# Altium schematic sheet EEPROM.SchDoc(EEPROM)
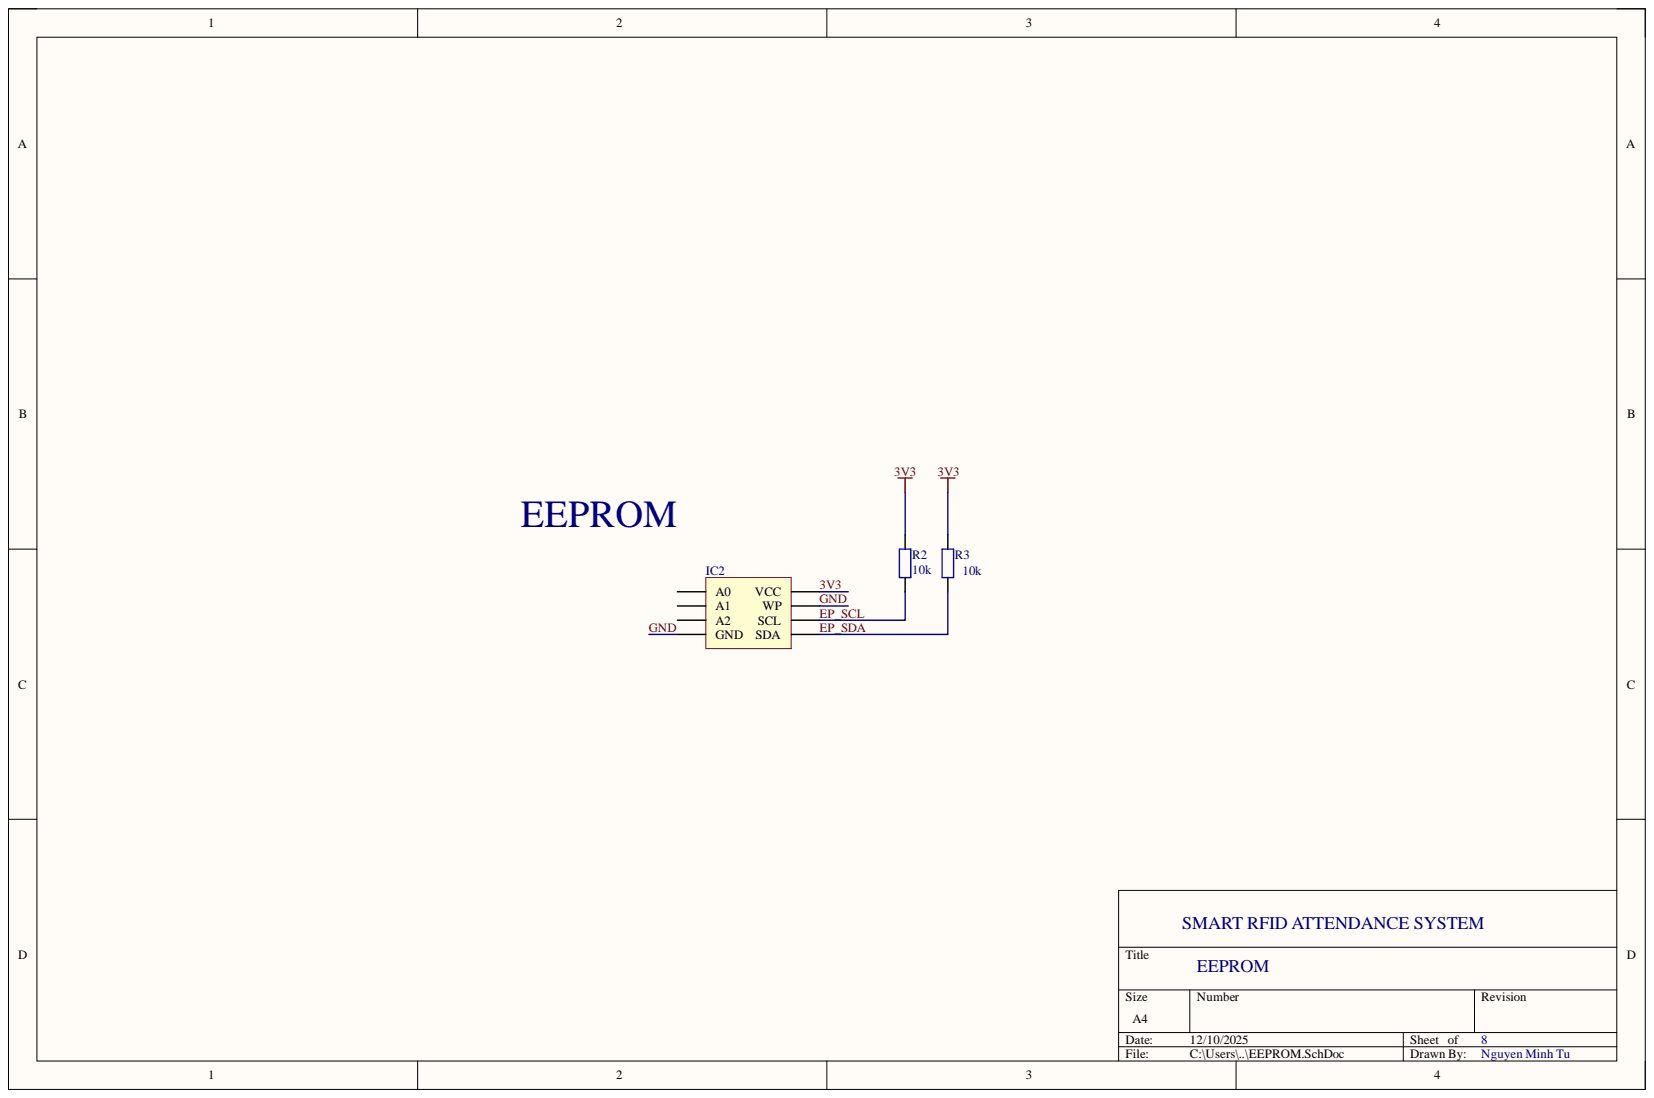
[diagram p0: EEPROM.SchDoc]

## Parts
IC2  MH002775  [DIP8]  (value not drawn)
R2  10k  [tro smd 0805]
R3  10k  [tro smd 0805]

## Nets
3V3: IC2.8(VCC) R2.2 R3.2
EP_SCL: IC2.6(SCL) R2.1
EP_SDA: IC2.5(SDA) R3.1
GND: IC2.4(GND) IC2.7(WP)

Not connected: IC2 1(A0) 2(A1) 3(A2)

## Library data of the parts
IC2 = AT24C01 DIP8 EEPROM: Cắm; Don_Vi: Con; Ten_Linh_Kien: AT24C01 DIP8 EEPROM 1KBIT; Chung_Loai: Cắm; Component Kind: Standard; Ma_Hang: MH002775; Library Name: Altium_Lib_by_KhueNguyenCreator.IntLib
R2 R3 = Tro 0805 1% 10k: Dán; Don_Vi: Con; Ma_Hang: MH005473; So_Chan: 2; Ten_Linh_Kien: Trở 0805 1% 10k; Chung_Loai: Dán; Component Kind: Standard; Library Name: Altium_Lib_by_KhueNguyenCreator.IntLib
